# Altium schematic sheet OV7725.SchDoc
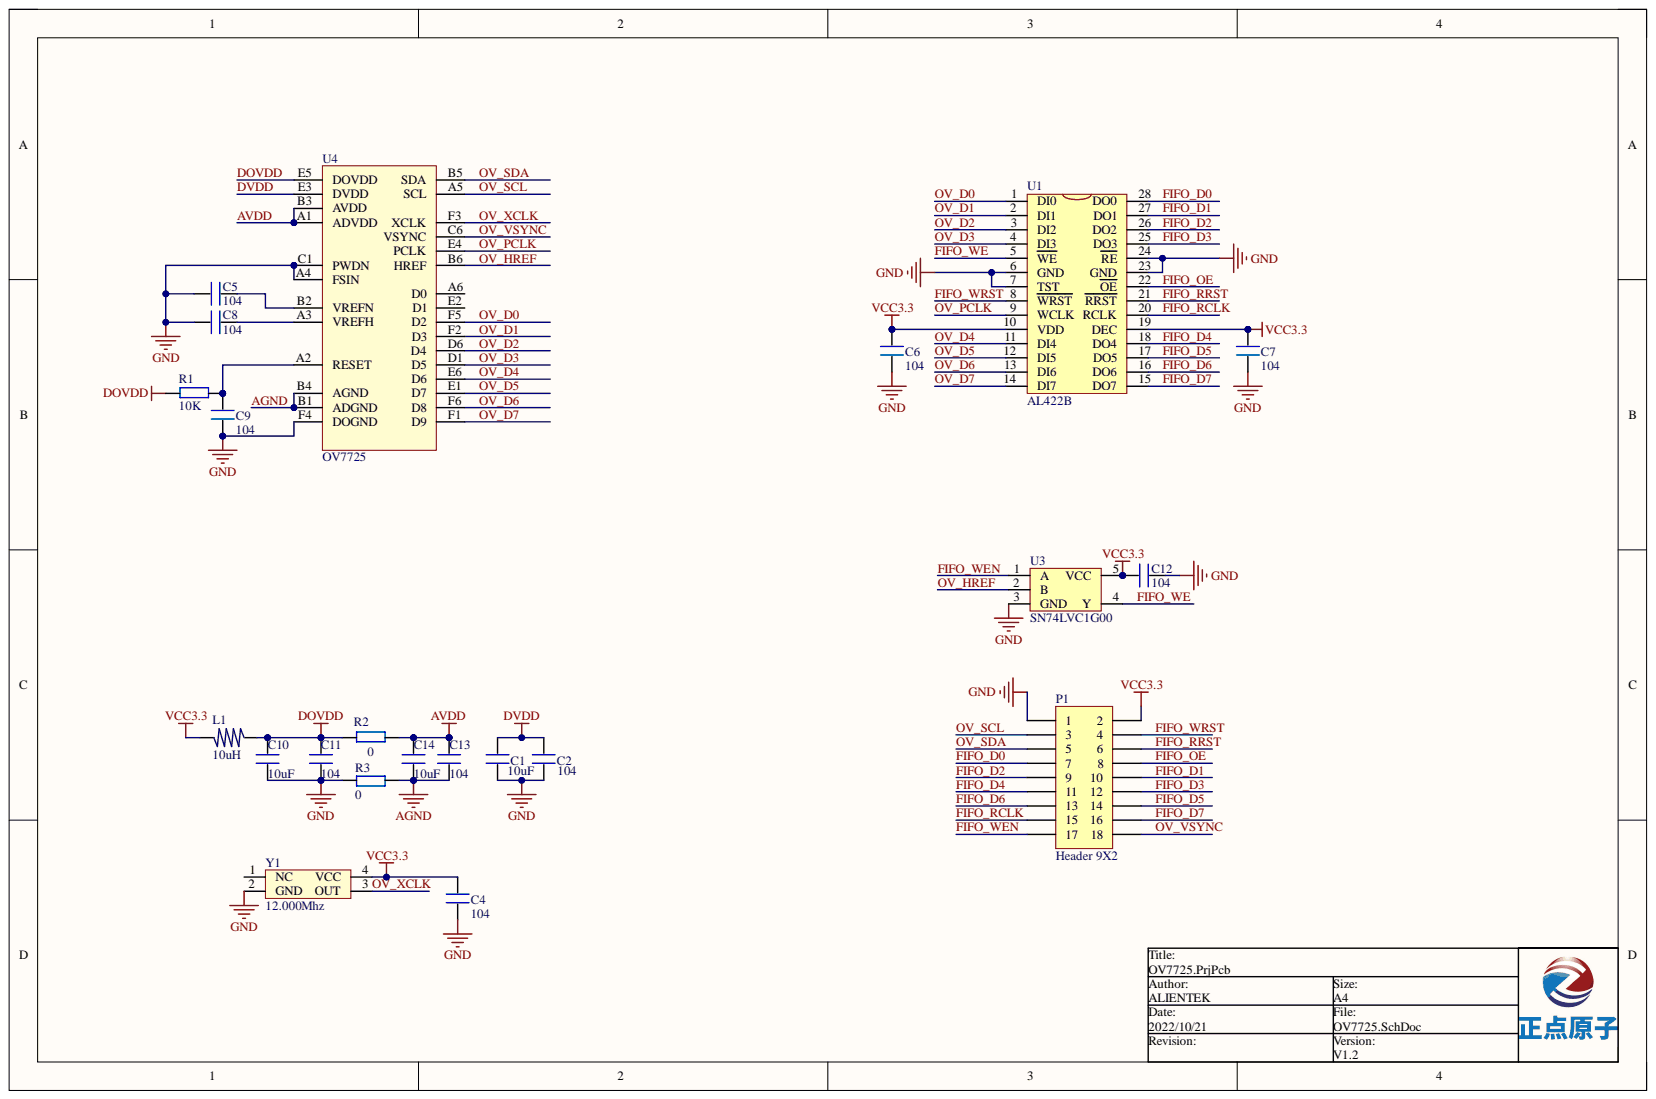
[diagram p0: OV7725.SchDoc]

## Parts
C1  10uF  [0603P]
C2  104  [0603P]
C4  104  [0603P]
C5  104  [0603P]
C6  104  [0603P]
C7  104  [0603P]
C8  104  [0603P]
C9  104  [0603P]
C10  10uF  [0805]
C11  104  [0603P]
C12  104  [0603P]
C13  104  [0603P]
C14  10uF  [0603P]
L1  10uH  [0805]
P1  Header 9X2  [HDR2X9]
R1  10K  [0603P]
R2  0  [0603P]
R3  0  [0603P]
U1  AL422B  [PSOP28]
U3  SN74LVC1G00  [SOT-23-5]
U4  OV7725  [OV7725-28]
Y1  12.000Mhz  [XTAL3225]

## Nets
GND: C1.1 C2.1 C4.1 C5.2 C6.2 C7.2 C8.2 C9.2 C10.1 C11.1 C12.2 P1.1 R3.1 U1.6(GND) U1.7(TST) U1.23(GND) U1.24(RE) U3.3(GND) U4.A4(FSIN) U4.C1(PWDN) U4.F4(DOGND) Y1.2(GND)
VCC3.3: C4.2 C6.1 C7.1 C12.1 L1.2 P1.2 U1.10(VDD) U1.19(DEC) U3.5(VCC) Y1.4(VCC)
AGND: C13.1 C14.1 R3.2 U4.B1(ADGND) U4.B4(AGND)
AVDD: C13.2 C14.2 R2.1 U4.A1(ADVDD) U4.B3(AVDD)
DOVDD: C10.2 C11.2 L1.1 R1.2 R2.2 U4.E5(DOVDD)
DVDD: C1.2 C2.2 U4.E3(DVDD)
FIFO_D0: P1.7 U1.28(DO0)
FIFO_D1: P1.10 U1.27(DO1)
FIFO_D2: P1.9 U1.26(DO2)
FIFO_D3: P1.12 U1.25(DO3)
FIFO_D4: P1.11 U1.18(DO4)
FIFO_D5: P1.14 U1.17(DO5)
FIFO_D6: P1.13 U1.16(DO6)
FIFO_D7: P1.16 U1.15(DO7)
FIFO_OE: P1.8 U1.22(OE)
FIFO_RCLK: P1.15 U1.20(RCLK)
FIFO_RRST: P1.6 U1.21(RRST)
FIFO_WE: U1.5(WE) U3.4(Y)
FIFO_WEN: P1.17 U3.1(A)
FIFO_WRST: P1.4 U1.8(WRST)
OV_D0: U1.1(DI0) U4.F5(D2)
OV_D1: U1.2(DI1) U4.F2(D3)
OV_D2: U1.3(DI2) U4.D6(D4)
OV_D3: U1.4(DI3) U4.D1(D5)
OV_D4: U1.11(DI4) U4.E6(D6)
OV_D5: U1.12(DI5) U4.E1(D7)
OV_D6: U1.13(DI6) U4.F6(D8)
OV_D7: U1.14(DI7) U4.F1(D9)
OV_HREF: U3.2(B) U4.B6(HREF)
OV_PCLK: U1.9(WCLK) U4.E4(PCLK)
OV_SCL: P1.3 U4.A5(SCL)
OV_SDA: P1.5 U4.B5(SDA)
OV_VSYNC: P1.18 U4.C6(VSYNC)
OV_XCLK: U4.F3(XCLK) Y1.3(OUT)
NetC5_1: C5.1 U4.B2(VREFN)
NetC8_1: C8.1 U4.A3(VREFH)
NetC9_1: C9.1 R1.1 U4.A2(RESET)

Not connected: U4 A6(D0) E2(D1); Y1 1(NC)

## Library data of the parts
C1 C2 C4-C14 = C: Component Kind: Standard
  C1 C2: Library Name: ATK-2014-09-12.SchLib
  C4-C14: Library Name: ATK-2014-09-12.PcbLib
L1 = L: Component Kind: Standard; Library Name: ATK-2014-09-12.SchLib
P1 = Header 9X2: Header, 9-Pin, Dual row; Component Kind: Standard; LatestRevisionDate: 17-Jul-2002; LatestRevisionNote: Re-released for DXP Platform.; Library Name: Miscellaneous Connectors.IntLib; Signal Integrity: Connector; Publisher: Altium Limited
R1-R3 = R: Component Kind: Standard
  R1: Library Name: ATK-2014-09-12.PcbLib
  R2: Library Name: MYCOMLIB.IntLib
  R3: Library Name: ATK-2014-09-12.SchLib
U1 = AL422B: FIFO; Component Kind: Standard; Library Name: ATK-2014-09-12.PcbLib
U3 = SN74LVC1G00: 2输入与非门; Component Kind: Standard; Library Name: ATK-2014-09-12.PcbLib
Y1 = XTAL_S: 有源晶振; Component Kind: Standard; Library Name: ATK-2014-09-12.PcbLib
U4 = OV7725: Component Kind: Standard; Library Name: ATK-2014-09-12.SchLib
